# Altium schematic sheet SLA-3000-FPC_Page01.SchDoc
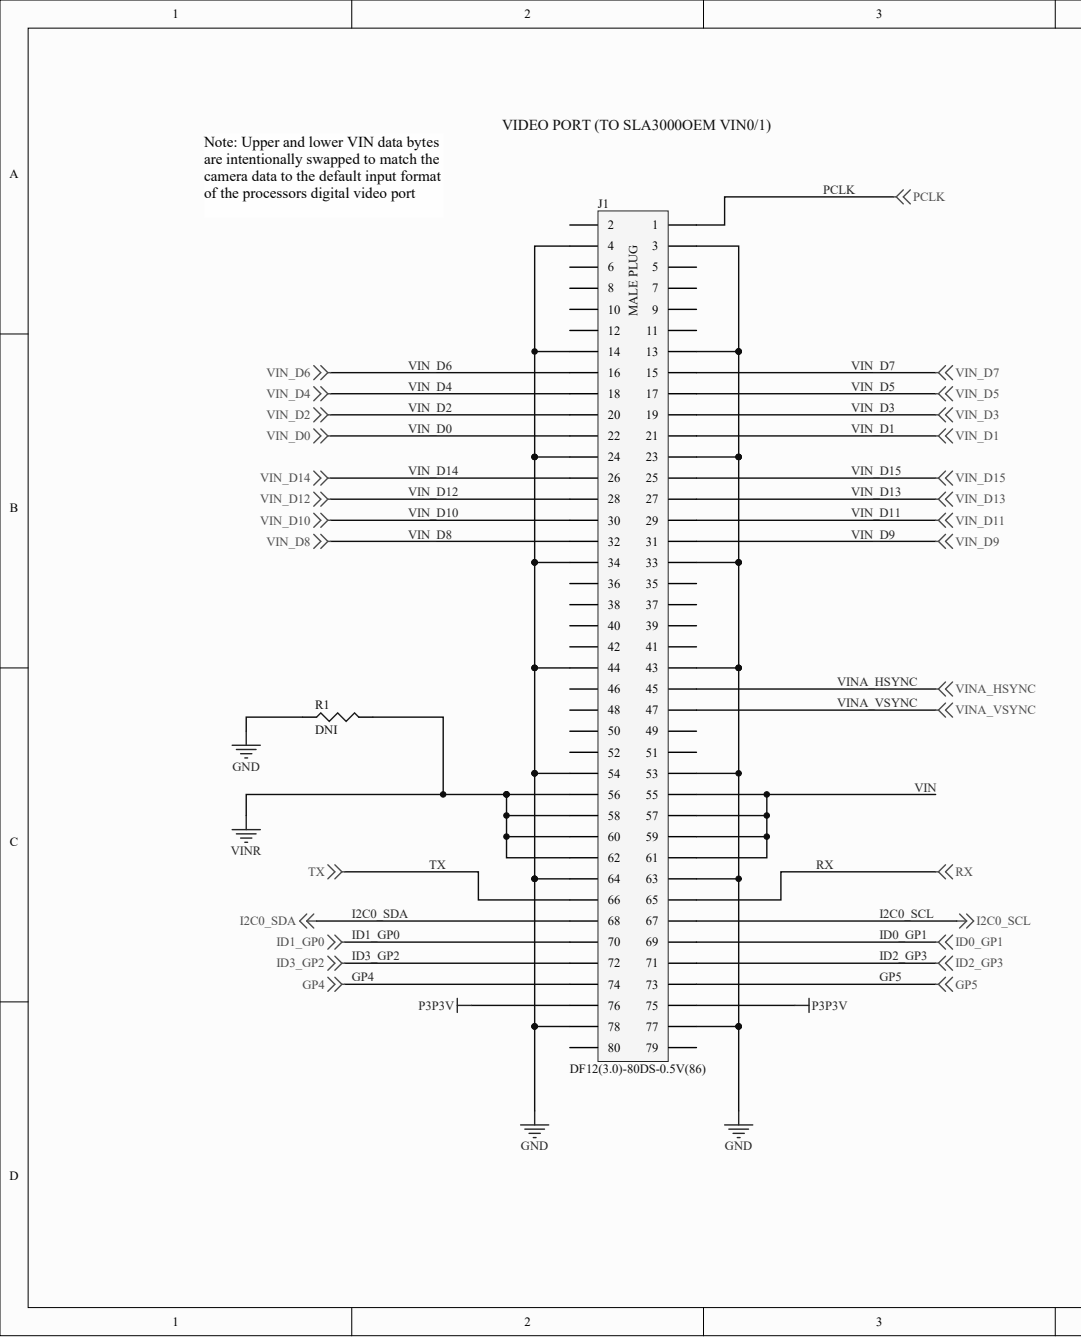
[diagram p0: SLA-3000-FPC_Page01.SchDoc, left]
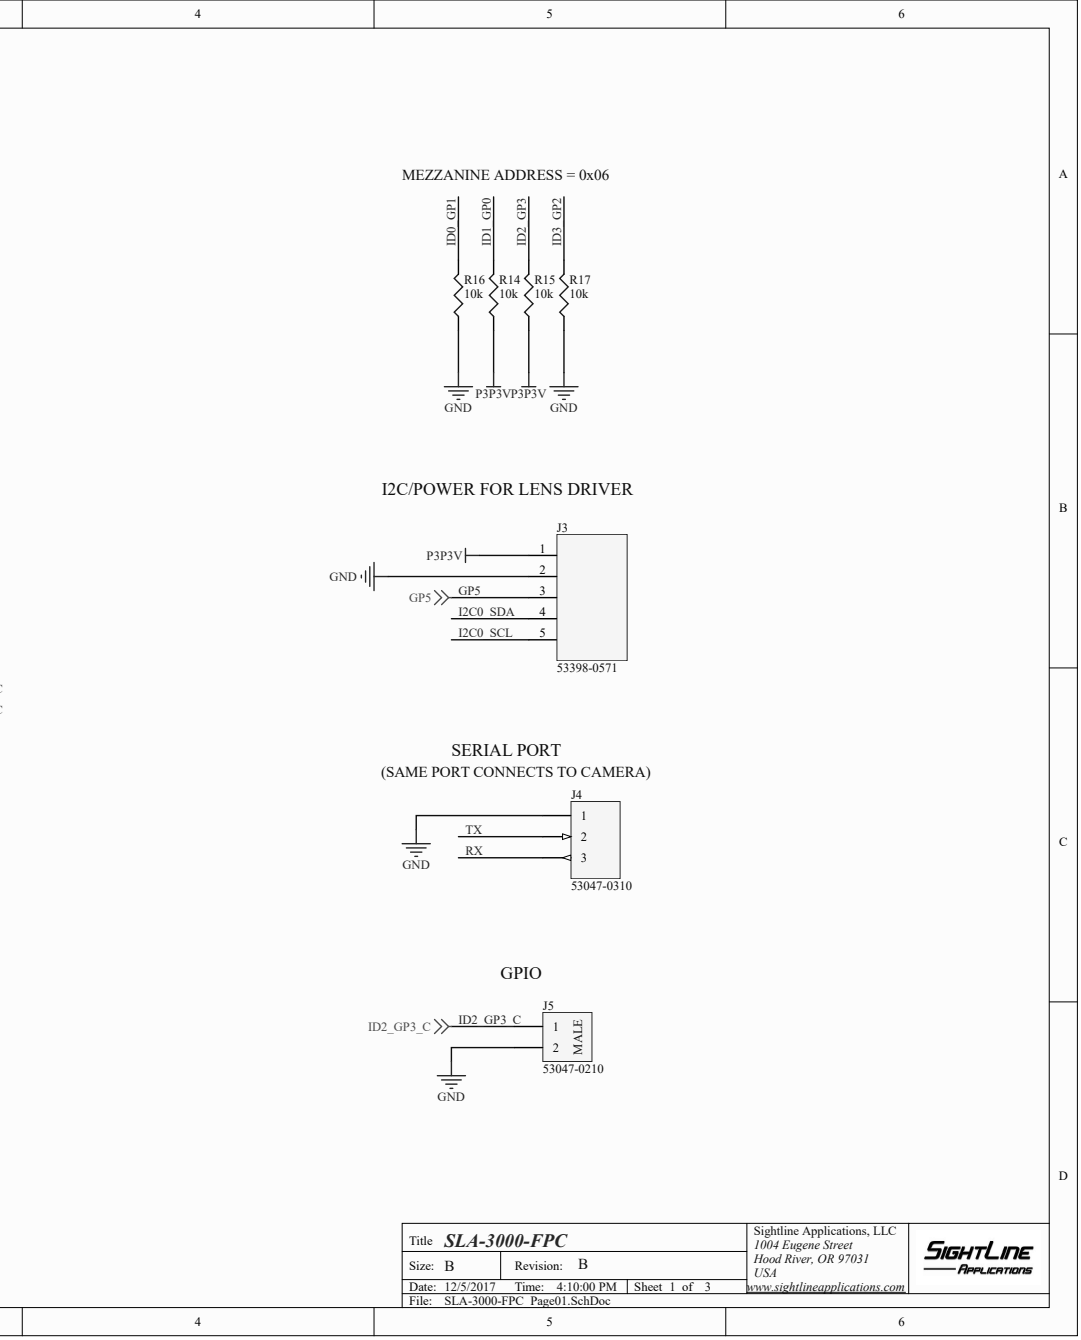
[diagram p1: SLA-3000-FPC_Page01.SchDoc, right]

## Parts
J1  DF12(3.0)-80DS-0.5V(86)
J3  53398-0571
J4  53047-0310
J5  53047-0210
R1  DNI
R14  10k
R15  10k
R16  10k
R17  10k

## Nets
GND: J1.3 J1.4 J1.13 J1.14 J1.23 J1.24 J1.33 J1.34 J1.43 J1.44 J1.53 J1.54 J1.63 J1.64 J1.77 J1.78 J3.2 J4.1 J5.2 R1.1 R16.1 R17.1
P3P3V: J1.75 J1.76 J3.1 R14.1 R15.1
VINR: J1.56 J1.58 J1.60 J1.62 R1.2
GP4: J1.74
GP5: J1.73 J3.3
I2C0_SCL: J1.67 J3.5
I2C0_SDA: J1.68 J3.4
ID0_GP1: J1.69 R16.2
ID1_GP0: J1.70 R14.2
ID2_GP3: J1.71 R15.2
ID2_GP3_C: J5.1
ID3_GP2: J1.72 R17.2
PCLK: J1.1
RX: J1.65 J4.3
TX: J1.66 J4.2
VIN: J1.55 J1.57 J1.59 J1.61
VIN_D0: J1.22
VIN_D1: J1.21
VIN_D2: J1.20
VIN_D3: J1.19
VIN_D4: J1.18
VIN_D5: J1.17
VIN_D6: J1.16
VIN_D7: J1.15
VIN_D8: J1.32
VIN_D9: J1.31
VIN_D10: J1.30
VIN_D11: J1.29
VIN_D12: J1.28
VIN_D13: J1.27
VIN_D14: J1.26
VIN_D15: J1.25
VINA_HSYNC: J1.45
VINA_VSYNC: J1.47

Not connected: J1 2 5 6 7 8 9 10 11 12 35 36 37 38 39 40 41 42 46 48 49 50 51 52 79 80

## Library data of the parts
J1 = (no symbol name): SLA Part No: SL00356; Female/Male: Male; Mfg: Hirose; Datasheet: http://www.hirose.co.jp/cataloge_hp/e53700036.pdf; MfgPartNo: DF12(3.0)-80DS-0.5V(86); HelpURL: http://www.hirose.co.jp/cataloge_hp/e53700036.pdf; Mounting Style: SMT
J3 = (no symbol name): SLA Part No: SL00454; Gender: Male; Mfg: Molex; HelpURL: http://www.molex.com/molex/products/datasheet.jsp?part=active/0533980571_PCB_HEADERS.xml; MfgPartNo: 53398-0571; Mounting: SMT
J4 = (no symbol name): SLA Part No: SL00531; Female/Male: Male; Mfg: Molex; Mounting Style: Thru-Hole; HelpURL: http://www.digikey.com/product-detail/en/0530470310/WM1732-ND/242854; MfgPartNo: 53047-0310; Gender: Male; Mounting: Thru-Hole; OriginalPartNumber: 53047-0310
J5 = (no symbol name): SLA Part No: SL00753; Gender: Male; Mfg: Molex; HelpURL: https://www.digikey.com/product-detail/en/molex-llc/0530470210/WM1731-ND/242853; MfgPartNo: 53047-0210; Mounting: Thru-Hole
R14-R17 = (no symbol name): MfgPartNo: ERJ-2RKF1002X; SLA Part No: SL00225; Mfg: Panasonic; Package: 402; Resistance: 10k
R1 = (no symbol name): Mfg: DNI; MfgPartNo: DNI; Package: 603; Resistance: DNI
